# Altium schematic sheet Tiresias_MCU.SchDoc
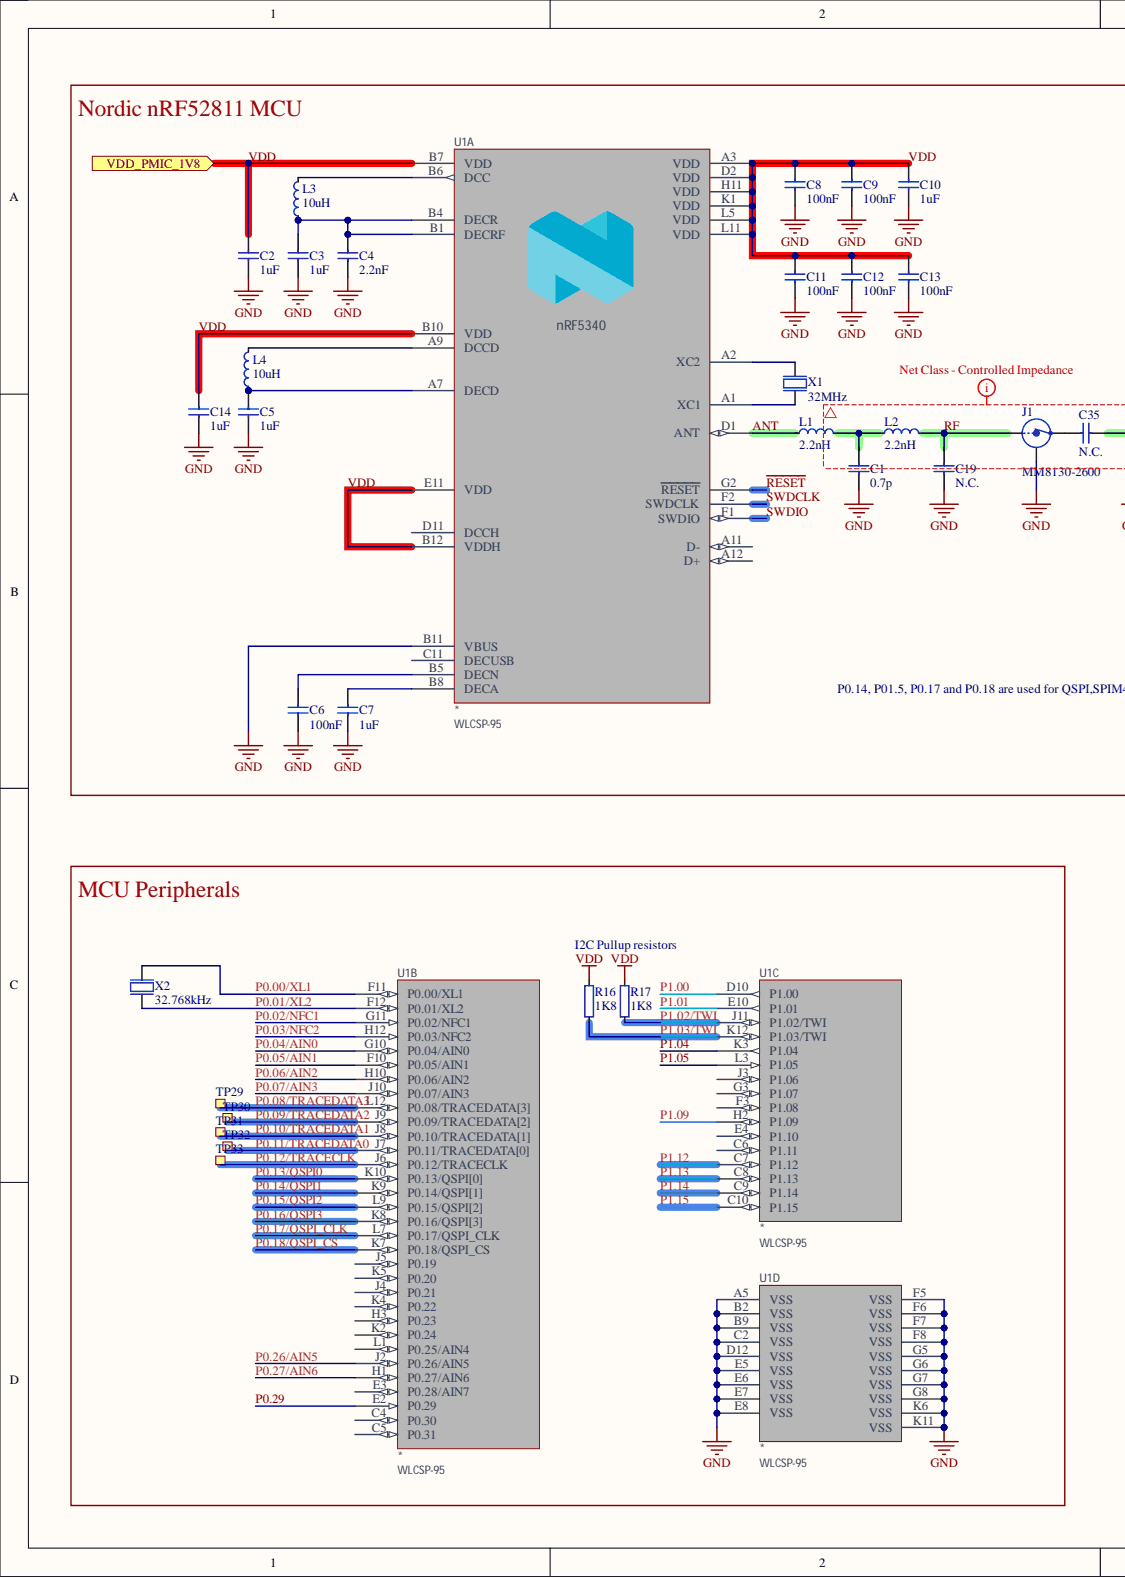
[diagram p0: Tiresias_MCU.SchDoc, left]
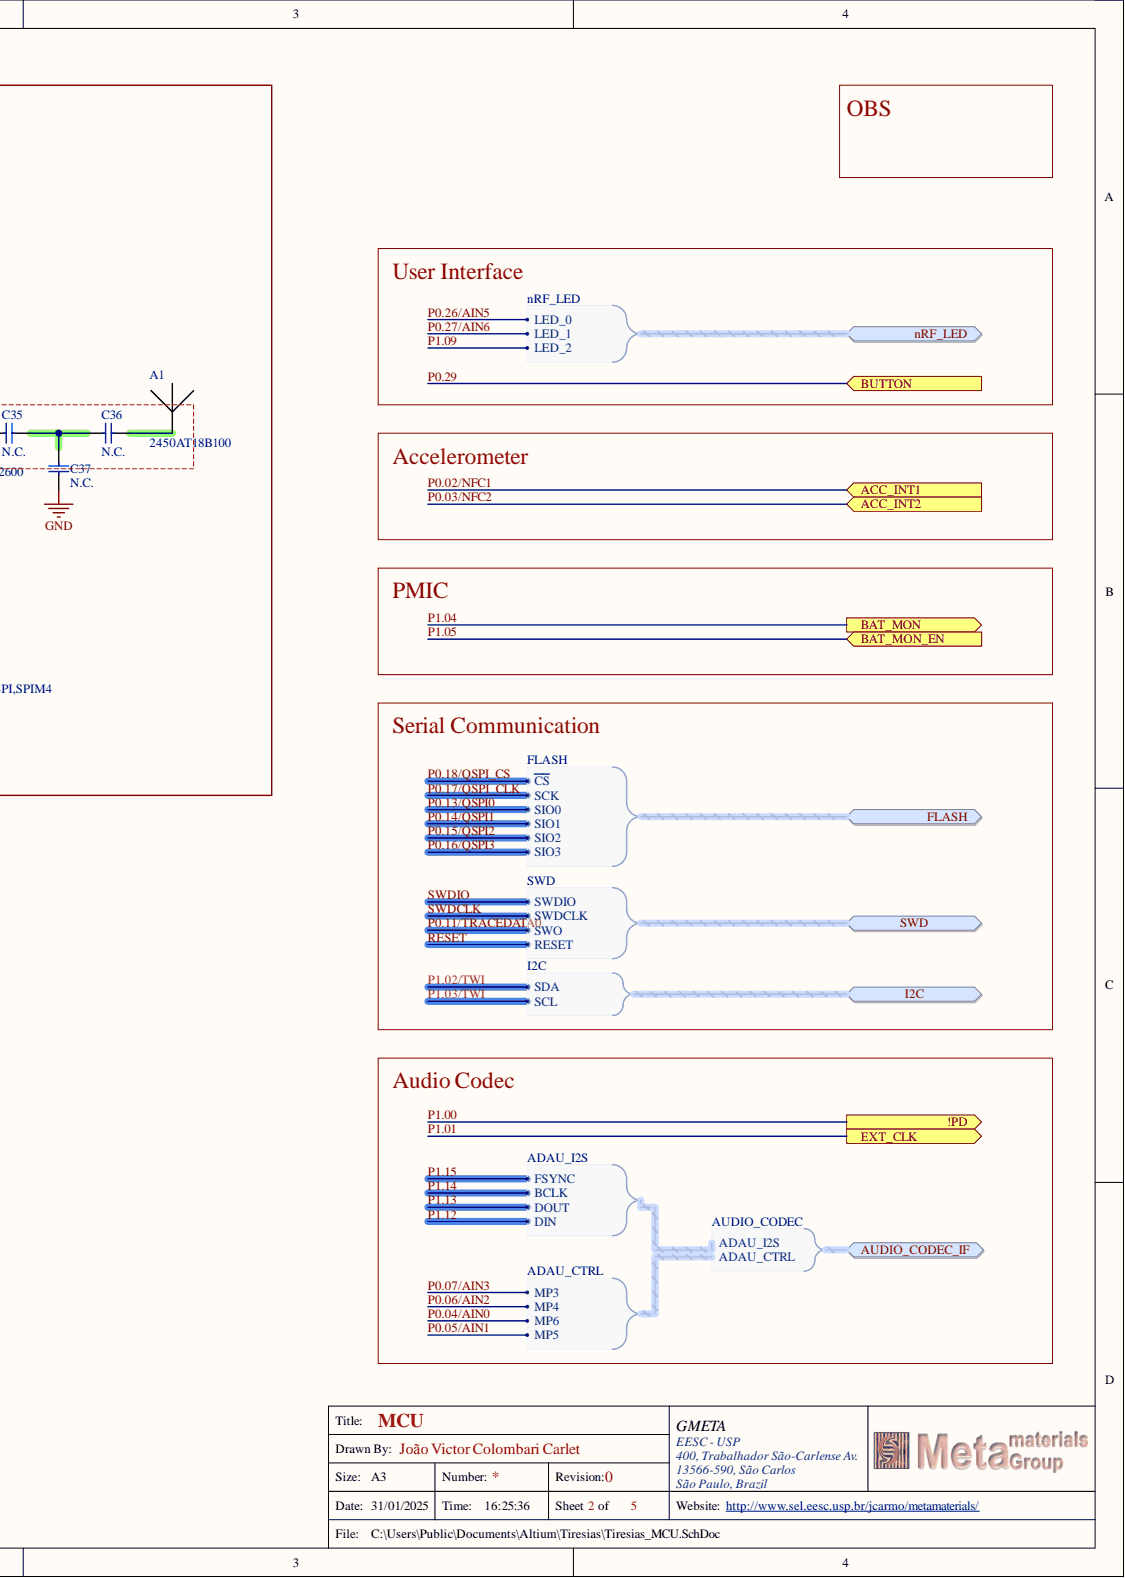
[diagram p1: Tiresias_MCU.SchDoc, right]

## Parts
A1  2450AT18B100  [2450AT18B100]
C1  0.7p  [CAPC0603X03L_C]
C2  1uF  [CAPC1005X04L]
C3  1uF  [CAPC1005X04L]
C4  2.2nF  [CAPC0603X03L_C]
C5  1uF  [CAPC1005X04L]
C6  100nF  [CAPC0603X03L_C]
C7  1uF  [CAPC1005X04L]
C8  100nF  [CAPC0603X03L_C]
C9  100nF  [CAPC0603X03L_C]
C10  1uF  [CAPC1005X04L]
C11  100nF  [CAPC0603X03L_C]
C12  100nF  [CAPC0603X03L_C]
C13  100nF  [CAPC0603X03L_C]
C14  1uF  [CAPC1005X04L]
C19  N.C.  [CAPC0603X03L_C_NC]
C35  N.C.  [CAPC0603X03L_C_NC]
C36  N.C.  [CAPC0603X03L_C_NC]
C37  N.C.  [CAPC0603X03L_C_NC]
J1  MM8130-2600  [COAXIAL-SWF]
L1  2.2nH  [INDC0603X03L_C]
L2  2.2nH  [INDC0603X03L_C]
L3  10uH  [INDC1608X06L]
L4  10uH  [INDC1608X06L]
R16  1K8  [RESC0603X03L_C]
R17  1K8  [RESC0603X03L_C]
TP29  Ø1.0mm,SMD  [TP_SMD_D1.0mm]  (value not drawn)  (no BOM)
TP30  Ø1.0mm,SMD  [TP_SMD_D1.0mm]  (value not drawn)  (no BOM)
TP31  Ø1.0mm,SMD  [TP_SMD_D1.0mm]  (value not drawn)  (no BOM)
TP32  Ø1.0mm,SMD  [TP_SMD_D1.0mm]  (value not drawn)  (no BOM)
TP33  Ø1.0mm,SMD  [TP_SMD_D1.0mm]  (value not drawn)  (no BOM)
U1  Package: WLCSP-95  [BGA95C35P12X11_437X397X50]  (parts A,B,C,D)
X1  32MHz  [BT-XTAL_2016]
X2  32.768kHz  [XTAL_2012]

## Ports
!PD, ACC_INT1, ACC_INT2, AUDIO_CODEC_IF, AUDIO_CODEC_IF.ADAU_CTRL, AUDIO_CODEC_IF.ADAU_CTRL.MP3, AUDIO_CODEC_IF.ADAU_CTRL.MP4, AUDIO_CODEC_IF.ADAU_CTRL.MP5, AUDIO_CODEC_IF.ADAU_CTRL.MP6, AUDIO_CODEC_IF.ADAU_I2S, AUDIO_CODEC_IF.ADAU_I2S.BCLK, AUDIO_CODEC_IF.ADAU_I2S.DIN, AUDIO_CODEC_IF.ADAU_I2S.DOUT, AUDIO_CODEC_IF.ADAU_I2S.FSYNC, BAT_MON, BAT_MON_EN, BUTTON, EXT_CLK, FLASH, FLASH.SCK, FLASH.SIO0, FLASH.SIO1, FLASH.SIO2, FLASH.SIO3, FLASH.~{CS}, I2C, I2C.SCL, I2C.SDA, nRF_LED, nRF_LED.LED_0, nRF_LED.LED_1, nRF_LED.LED_2, SWD, SWD.RESET, SWD.SWDCLK, SWD.SWDIO, SWD.SWO, VDD_PMIC_1V8

## Nets
GND: C1.1 C2.1 C3.1 C4.1 C5.1 C6.1 C7.1 C8.1 C9.1 C10.1 C11.1 C12.1 C13.1 C14.1 C19.1 C37.1 J1.2 U1.A5(VSS) U1.B2(VSS) U1.B9(VSS) U1.B11(VBUS) U1.C2(VSS) U1.D12(VSS) U1.E5(VSS) U1.E6(VSS) U1.E7(VSS) U1.E8(VSS) U1.F5(VSS) U1.F6(VSS) U1.F7(VSS) U1.F8(VSS) U1.G5(VSS) U1.G6(VSS) U1.G7(VSS) U1.G8(VSS) U1.K6(VSS) U1.K11(VSS) X1.2 X1.4
ANT: L1.1 U1.D1(ANT)
P0.00/XL1: U1.F11(P0.00/XL1) X2.2
P0.01/XL2: U1.F12(P0.01/XL2) X2.1
P0.02/NFC1: U1.G11(P0.02/NFC1) ; port ACC_INT1
P0.03/NFC2: U1.H12(P0.03/NFC2) ; port ACC_INT2
P0.04/AIN0: U1.G10(P0.04/AIN0) ; port AUDIO_CODEC_IF
P0.05/AIN1: U1.F10(P0.05/AIN1) ; port AUDIO_CODEC_IF
P0.06/AIN2: U1.H10(P0.06/AIN2) ; port AUDIO_CODEC_IF
P0.07/AIN3: U1.J10(P0.07/AIN3) ; port AUDIO_CODEC_IF
P0.08/TRACEDATA3: TP29.1 U1.L12(P0.08/TRACEDATA[3])
P0.09/TRACEDATA2: TP30.1 U1.J9(P0.09/TRACEDATA[2])
P0.10/TRACEDATA1: TP31.1 U1.J8(P0.10/TRACEDATA[1])
P0.11/TRACEDATA0: TP32.1 U1.J7(P0.11/TRACEDATA[0]) ; port SWD
P0.12/TRACECLK: TP33.1 U1.J6(P0.12/TRACECLK)
P0.13/QSPI0: U1.K10(P0.13/QSPI[0]) ; port FLASH
P0.14/QSPI1: U1.K9(P0.14/QSPI[1]) ; port FLASH
P0.15/QSPI2: U1.L9(P0.15/QSPI[2]) ; port FLASH
P0.16/QSPI3: U1.K8(P0.16/QSPI[3]) ; port FLASH
P0.17/QSPI_CLK: U1.L7(P0.17/QSPI_CLK) ; port FLASH
P0.18/QSPI_CS: U1.K7(P0.18/QSPI_CS) ; port FLASH
P0.26/AIN5: U1.J2(P0.26/AIN5) ; port nRF_LED
P0.27/AIN6: U1.H1(P0.27/AIN6) ; port nRF_LED
P0.29: U1.E2(P0.29) ; port BUTTON
P1.00: U1.D10(P1.00) ; port !PD
P1.01: U1.E10(P1.01) ; port EXT_CLK
P1.02/TWI: R17.1 U1.J11(P1.02/TWI) ; port I2C
P1.03/TWI: R16.1 U1.K12(P1.03/TWI) ; port I2C
P1.04: U1.K3(P1.04) ; port BAT_MON
P1.05: U1.L3(P1.05) ; port BAT_MON_EN
P1.09: U1.H2(P1.09) ; port nRF_LED
P1.12: U1.C7(P1.12) ; port AUDIO_CODEC_IF
P1.13: U1.C8(P1.13) ; port AUDIO_CODEC_IF
P1.14: U1.C9(P1.14) ; port AUDIO_CODEC_IF
P1.15: U1.C10(P1.15) ; port AUDIO_CODEC_IF
~{RESET}: U1.G2(RESET) ; port SWD
RF: C19.2 J1.1 L2.2
SWDCLK: U1.F2(SWDCLK) ; port SWD
SWDIO: U1.F1(SWDIO) ; port SWD
VDD: C2.2 C8.2 C9.2 C10.2 C11.2 C12.2 C13.2 C14.2 R16.2 R17.2 U1.A3(VDD) U1.B7(VDD) U1.B10(VDD) U1.B12(VDDH) U1.D2(VDD) U1.E11(VDD) U1.H11(VDD) U1.K1(VDD) U1.L5(VDD) U1.L11(VDD) ; port VDD_PMIC_1V8
NetA1_1: A1.1 C36.1
NetC1_2: C1.2 L1.2 L2.1
NetC3_2: C3.2 C4.2 L3.1 U1.B1(DECRF) U1.B4(DECR)
NetC5_2: C5.2 L4.1 U1.A7(DECD)
NetC6_2: C6.2 U1.B5(DECN)
NetC7_2: C7.2 U1.B8(DECA)
NetC35_1: C35.1 J1.3
NetC35_2: C35.2 C36.2 C37.2
NetL3_2: L3.2 U1.B6(DCC)
NetL4_2: L4.2 U1.A9(DCCD)
NetU1_A1: U1.A1(XC1) X1.1
NetU1_A2: U1.A2(XC2) X1.3
NetU1_A11: U1.A11(D-)
NetU1_A12: U1.A12(D+)
NetU1_C4: U1.C4(P0.30)
NetU1_C5: U1.C5(P0.31)
NetU1_C6: U1.C6(P1.11)
NetU1_C11: U1.C11(DECUSB)
NetU1_D11: U1.D11(DCCH)
NetU1_E3: U1.E3(P0.28/AIN7)
NetU1_E4: U1.E4(P1.10)
NetU1_F3: U1.F3(P1.08)
NetU1_G3: U1.G3(P1.07)
NetU1_H3: U1.H3(P0.23)
NetU1_J3: U1.J3(P1.06)
NetU1_J4: U1.J4(P0.21)
NetU1_J5: U1.J5(P0.19)
NetU1_K2: U1.K2(P0.24)
NetU1_K4: U1.K4(P0.22)
NetU1_K5: U1.K5(P0.20)
NetU1_L1: U1.L1(P0.25/AIN4)

## Library data of the parts
A1 = Johanson 2450AT18B100: 2.45 GHz chip antenna; Manufacturer: Johanson Technology; Part number IPS: 00000007781; Artikkelnr Noca: N.A.; Pad Count: 2; Component Class: Antennas; Component Kind: Standard; ComponentLink1Description: Datasheet; ComponentLink1URL: N.A.; ComponentLink2Description: Supplier link; ComponentLink2URL: N.A.; Footprint Doc: 2450AT18B100; HelpURL: http://www.johansontechnology.com/datasheets/antennas/2450AT18B100.pdf; LatestRevisionDate: 2013-09-13; Manufacturer Part Number: 2450AT18B100; Note: N.A.; Part Number: Johanson 2450AT18B100; Part number Norautron: N.A.; PartId: 100700001; Published: 2013-09-13; Supplier 1: Digi-Key; Supplier 2: N.A.; Supplier Part Number 1: 712-1006-1-ND; Supplier Part Number 2: N.A.; Value: 2450AT18B100; Author: rsk; Library Name: Tiresias_passive.SchLib
C1 = CAP_0201_0p7: Capacitor, NP0, ±2%; Part Number: C0201-0p7; Class: SMT; Component Kind: Standard; LatestRevisionDate: 2024-03-04; Package: 0201; Pin Count: 2; Value: 0.7p; Library Name: Tiresias_passive.SchLib
C2 C3 C5 C7 C10 C14 = CAP_0402_1uF: Capacitor, X5R, ±5%; Part Number: C0402-1µ0; Class: SMT; Component Kind: Standard; LatestRevisionDate: 2024/02/20; Package: 0402; Pin Count: 2; Value: 1uF; Library Name: Tiresias_passive.SchLib
C4 = CAP_0201_2n2: Capacitor, NP0, ±2%; Part Number: C0201-2n2; Class: SMT; Component Kind: Standard; LatestRevisionDate: 2024/03/02; Package: 0201; Pin Count: 2; Value: 2.2nF; Library Name: Tiresias_passive.SchLib
C6 C8 C9 C11-C13 = CAP_0201_100nF: Capacitor, NP0, ±2%; Part Number: C0201-100n; Class: SMT; Component Kind: Standard; LatestRevisionDate: 2024/02/20; Package: 0201; Pin Count: 2; Value: 100nF; Library Name: Tiresias_passive.SchLib
C19 C35-C37 = CAP_0201_NC: Capacitor, NP0, ±2%; Part Number: C0201-NC; Component Kind: Standard; LatestRevisionDate: 2024-03-04; Pin Count: 2; Value: N.C.; Library Name: Tiresias_passive.SchLib
J1 = MM8130-2600: Coaxial Connector with Switch; Manufacturer: Murata; Part number IPS: 00000009955; Artikkelnr Noca: 55029011; Note: N.A.; Pad Count: 3; Component Class: Connectors; Component Kind: Standard; ComponentLink1Description: Datasheet; ComponentLink1URL: N.A.; ComponentLink2Description: Supplier link; ComponentLink2URL: N.A.; Footprint Doc: COAXIAL-SWF; HelpURL: http://www.murata.com/products/catalog/pdf/o33e.pdf; LatestRevisionDate: 2014-04-24; Manufacturer Part Number: MM8130-2600; Part Number: MM8130-2600; Part number Norautron: N.A.; PartId: 100500400; Published: 2014-04-24; Supplier 1: Digi-Key; Supplier 2: Mouser; Supplier Part Number 1: 490-4981-1-ND; Supplier Part Number 2: 81-MM8130-2600RA2; Value: MM8130-2600; Author: rsk; Library Name: Tiresias_mechanical.SchLib
L1 L2 = IND_0201_2n2H: High frequency chip inductor ±5%; Part Number: L0201-2n2; Class: SMT; Component Kind: Standard; LatestRevisionDate: 2024/02/20; Package: 0201; Pin Count: 2; Value: 2.2nH; Library Name: Tiresias_passive.SchLib
L3 L4 = IND_0603_10uH: Chip inductor, IDC,min = 50 mA, ±20%; Part Number: L0603-10µ; Class: SMT; Component Kind: Standard; LatestRevisionDate: 2024/02/20; Package: 0603; Pin Count: 2; Value: 10uH; Library Name: Tiresias_passive.SchLib
R16 R17 = RES_0201_1K8: Resistor, ±1%, 0.05W; Manufacturer: N.A.; Part Number: R0201-100k; Class: SMT; Component Kind: Standard; ComponentLink1Description: Datasheet; ComponentLink1URL: N.A.; ComponentLink2Description: Supplier link; ComponentLink2URL: N.A.; DigiKey link: 00000001419; JLCpcb link: Chip Res 1%; Latest Revision Date: 2024-04-23; Mouser link: N.A.; Package: 0201; Pin Count: 2; Value: 1K8; Author: rsk; Library Name: Tiresias_passive.SchLib
TP29-TP33 = TP_SMD_Ø1.0MM: 1.0mm circular SMD testpad; Manufacturer: Nordic Semiconductor; Artikkelnr Noca: N.A.; Pad Count: 1; Component Class: Connectors; ComponentLink1Description: Datasheet; ComponentLink1URL: N.A.; ComponentLink2Description: Supplier link; ComponentLink2URL: N.A.; Footprint Doc: TP_SMD_Ø1.0mm; HelpURL: N.A.; LatestRevisionDate: 2021-03-05; Manufacturer Part Number: N.A.; Note: N.A.; Part Number: TP_SMD_Ø1.0MM; Part number IPS: N.A.; Part number Norautron: N.A.; PartId: 100500475; Published: 2013-06-11; Supplier 1: N.A.; Supplier 2: N.A.; Supplier Part Number 1: N.A.; Supplier Part Number 2: N.A.; Value: Ø1.0mm,SMD; Author: rsk; Library Name: Tiresias_mechanical.SchLib
U1 = nRF5340-CLAA: Multi-protocol Bluetooth Low Energy, IEEE 802.15.4, ANT and 2.4GHz proprietary system-on-chip; Manufacturer: Nordic Semiconductors; Class: logic; Component Kind: Standard; Latest Revision Date: 2024/03/04; Manufacturer Part Number: nRF5340-CLAA; Part Number: nRF5340-CLAA; Pin Count: 95; Library Name: Tiresias_logic.SchLib
X1 = XTAL_SMD2016_32MHz: XTAL SMD 2016, 32MHz, Cl=8pF, Total Tol: ±40ppm; Part Number: XTAL_SMD2016_32MHz_8pF_General; Class: SMT; Component Kind: Standard; LatestRevisionDate: 2024/02/20; Package: 2016; Pin Count: 4; Value: 32MHz; Library Name: Tiresias_passive.SchLib
X2 = XTAL_SMD2012_32k768Hz: XTAL SMD 2012, 32.768kHz, Cl=9pF, Total Tol: ±50ppm; Part Number: XTAL_SMD2012_32.768kHz_9pF_General; Class: SMT; Component Kind: Standard; LatestRevisionDate: 2024/02/20; Package: 2012; Pin Count: 4; Value: 32.768kHz; Library Name: Tiresias_passive.SchLib
